# Altium schematic sheet Sheet1.SchDoc(Sheet1)
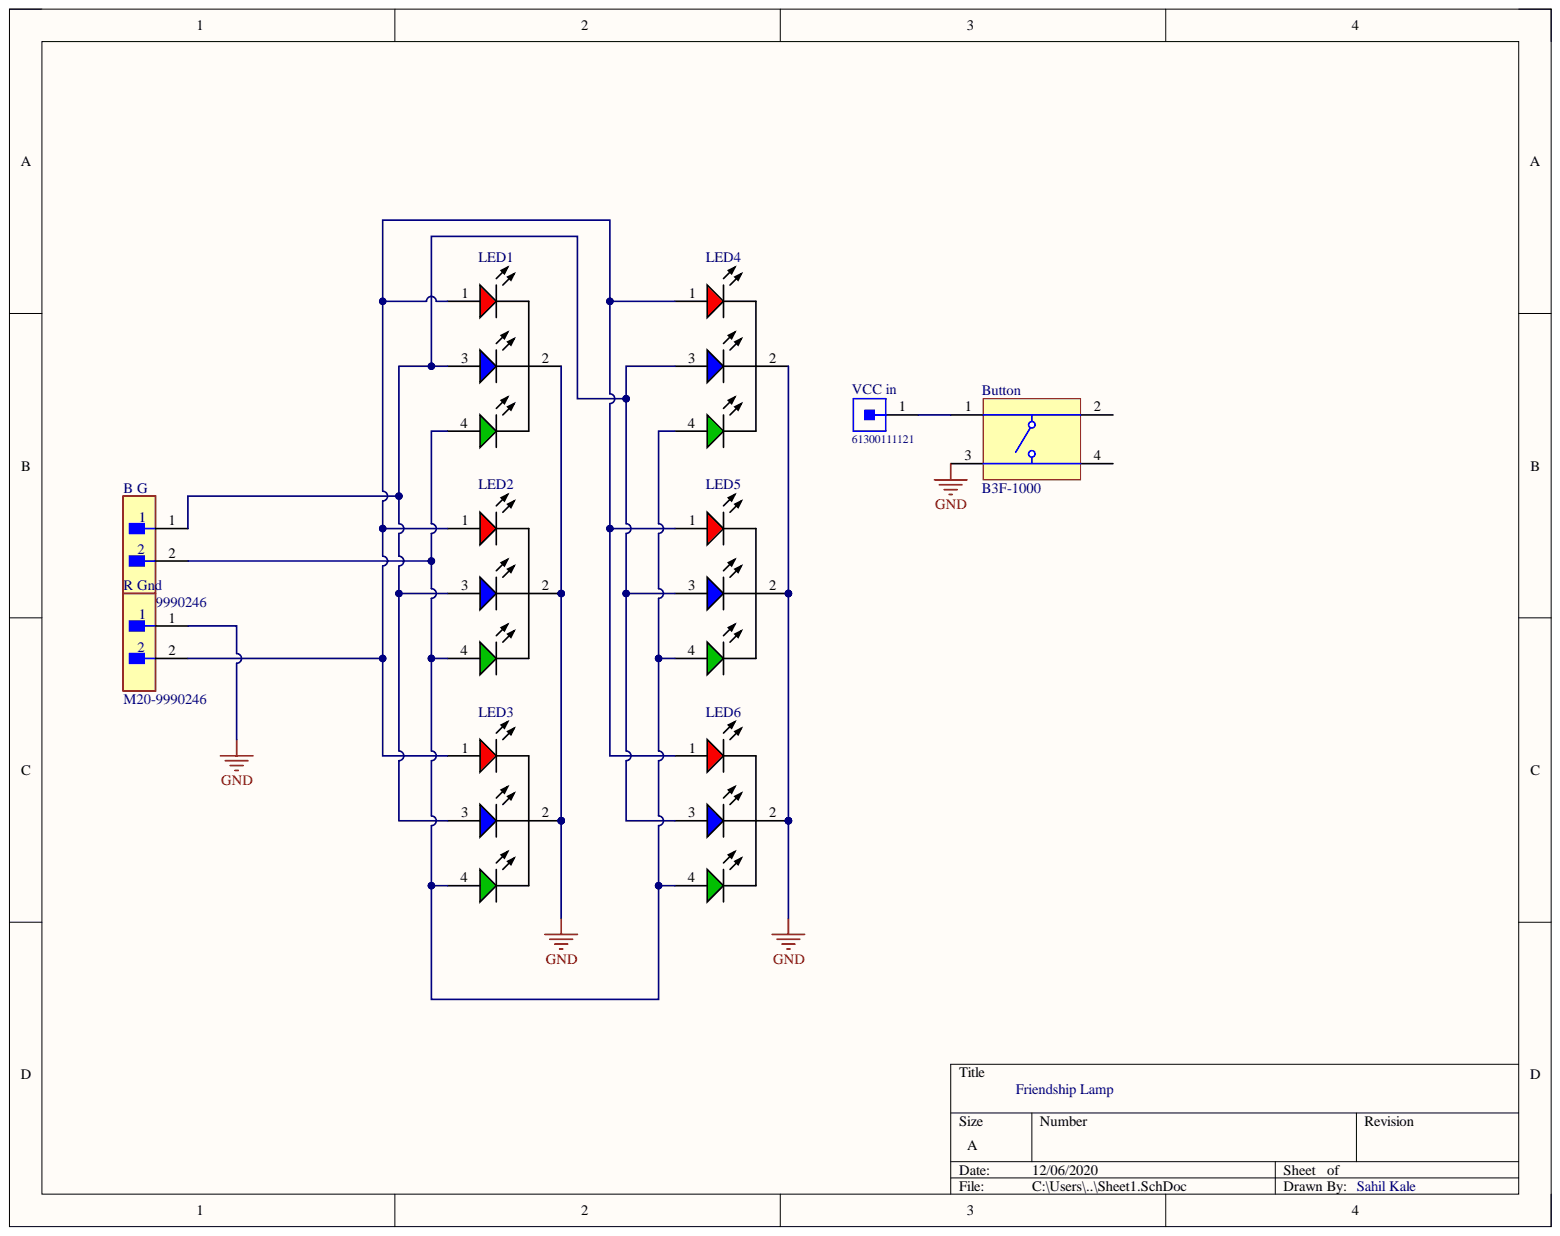
[diagram p0: Sheet1.SchDoc]

## Parts
B_G  M20-9990246  [FP-M20-9990246-MFG]
Button  B3F-1000  [OMRON-B3F-1000-4_V]
LED1  (no value)  [KING-WP154A4SUREQBFZGC_V]
LED2  (no value)  [KING-WP154A4SUREQBFZGC_V]
LED3  (no value)  [KING-WP154A4SUREQBFZGC_V]
LED4  (no value)  [KING-WP154A4SUREQBFZGC_V]
LED5  (no value)  [KING-WP154A4SUREQBFZGC_V]
LED6  (no value)  [KING-WP154A4SUREQBFZGC_V]
R_Gnd  M20-9990246  [FP-M20-9990246-MFG]
VCC_in  61300111121  [61300111121]

## Nets
GND: Button.3 LED1.2 LED2.2 LED3.2 LED4.2 LED5.2 LED6.2 R_Gnd.1
NetB G_1: B_G.1 LED1.3 LED2.3 LED3.3 LED4.3 LED5.3 LED6.3
NetB G_2: B_G.2 LED1.4 LED2.4 LED3.4 LED4.4 LED5.4 LED6.4
NetButton_1: Button.1 VCC_in.1
NetLED1_1: LED1.1 LED2.1 LED3.1 LED4.1 LED5.1 LED6.1 R_Gnd.2

Not connected: Button 2 4

## Library data of the parts
B_G R_Gnd = CMP-15831-000009-1: CONN HEADER VERT 2POS 2.54MM; Manufacturer: Harwin; Conn Gender: Male; Conn Orientation: Vertical; Current Rating: 3A; Number Of Contacts: 2; Automotive: No; Category: Conn; Component Kind: Standard; ComponentLink2Description: Datasheet; ComponentLink2URL: https://cdn.harwin.com/pdfs/M20-999.pdf; Contact Material: Tin,Nickel; Device Class L1: Connectors; Device Class L2: Headers and Wire Housings; Device Class L3: unset; Digikey Description: CONN HEADER VERT 2POS 2.54MM; Height: 8.99mm; Lead Free: Yes; Library Name: Altium Content Vault; Manufacturer Part Number: M20-9990246; Mouser Description: 2.54mm (0.1") Pitch SIL Vertical PC Tail Pin Header, 6.1mm mating pin height, tin, 2 contacts; Number Of Rows: 1; Octopart: https://octopart.com/m20-9990246-harwin-2590635; Package: M20-9990246; Pitch: 2.54mm; RoHS: TRUE; Temperature: -40°C to +105°C; Temperature Range High: +105°C; Temperature Range Low: -40°C
Button = CMP-1677-00002-1: Tactile Switch, SPST-NO, 0.05 A, 5 to 24 V, -25 to 70 degC, 4-Pin THD, RoHS, Bulk; Capacitance: -45 f; Current Rating: 1.5 A; Gender: Female; Shielding: Shielded; Voltage Rating: 150 V; Component Kind: Standard; ComponentLink1Description: Manufacturer URL; ComponentLink1URL: http://www.omron.com/; ComponentLink2Description: Datasheet; ComponentLink2URL: http://www.omron.com/ecb/products/pdf/en-b3f.pdf; Contact Material: Brass; Contact Plating: Gold; DatasheetVersion: Jan-15; Height: 14.99 mm; Lead Pitch: 1.27 mm; Length: 18.34 mm; Library Name: Altium Content Vault; Max Operating Temperature: 70 degC; Min Operating Temperature: -40 degC; Mount: Through Hole; Package Quantity: 1080; PackageDescription: 4-Pin Through Hole Device, Body 6 x 6 mm; PackageReference: B3F-1000; Packaging: Tray; Pins: 8; Radiation Hardening: No; RoHSCompliant: true; Width: 25.4 mm
LED1-LED6 = CMP-2000-07415-1: Full Color LED Lamp, Dominant Wavelength RGB 630 / 525 / 465 nm, -40 to 85 degC, 4-Pin THD, RoHS; Manufacturer: Kingbright; 3D Model: WP154A4SUREQBFZGW.sldprt    WP154A4SUREQBFZGW.pro    WP154A4SUREQBFZGW.igs    WP154A4SUREQBFZGW.stp; Color: Red,Green,Blue(RGB); Current - Test: 20mA; Lens Style/Size: RoundwithDomedTop,5mm,T-13/4; Lens Type: Clear; Millicandela Rating: 1300mcdRed,1700mcdGreen,900mcdBlue; Online Catalog: 5mm,T-13/4(RGB)RoundwithDomedTopLEDLamp; Other Names: 754-1615  WP154A4SUREQBFZGC-ND; Viewing Angle: 50°; Voltage - Forward (Vf) (Typ): 1.9VRed,3.3VGreen,3.3VBlue; Wavelength - Dominant: 630nm,525nm,465nm; Wavelength - Peak: 645nm,515nm,460nm; Category: Optoelectronics; Component Kind: Standard; Datasheet URL: https://www.kingbrightusa.com/images/catalog/spec/WP154A4SUREQBFZGC.pdf; Datasheet Version: Rev. 5A, 08/2014; Family: LEDIndication-Discrete; Height: 8.60mm; Library Name: Altium Content Vault; Manufacturer URL: http://www.kingbright.com/; Mounting Technology: ThroughHole; Mounting Type: ThroughHole; Package / Case: Radial-4Leads; Package Description: 4-Pin Through Hole LED, Dia 5.9 mm, Pitch 1.27 mm; Package Reference: WP154A4SUREQBFZGC; Packaging: Bulk; Standard Package: 500
VCC_in = CMP-1502-01058-1: THT Vertical Pin Header WR-PHD, Pitch 2.54 mm, Single Row, 1 pins; Manufacturer: Wurth Elektronik; Contact Resistance: 20mOhm; Gender: Male; Pin Number: 1; Pitch: 2.54mm; Rated Current: 3A; Withstanding Voltage: 500VAC; Working Voltage: 250VAC; Component Kind: Standard; Datasheet URL: https://katalog.we-online.de/em/datasheet/6130xx11121.pdf; Library Name: Altium Content Vault; Manufacturer URL: http://www.we-online.de; Mounting Technology: THT; Operating Temperature: -40to105degC; Orientation: Vertical; Package Reference: 61300111121; Packaging: Bag; Part Number: 61300111121; Row Number: Single; Publisher: WurthElektronik
